# Altium schematic sheet power.SchDoc
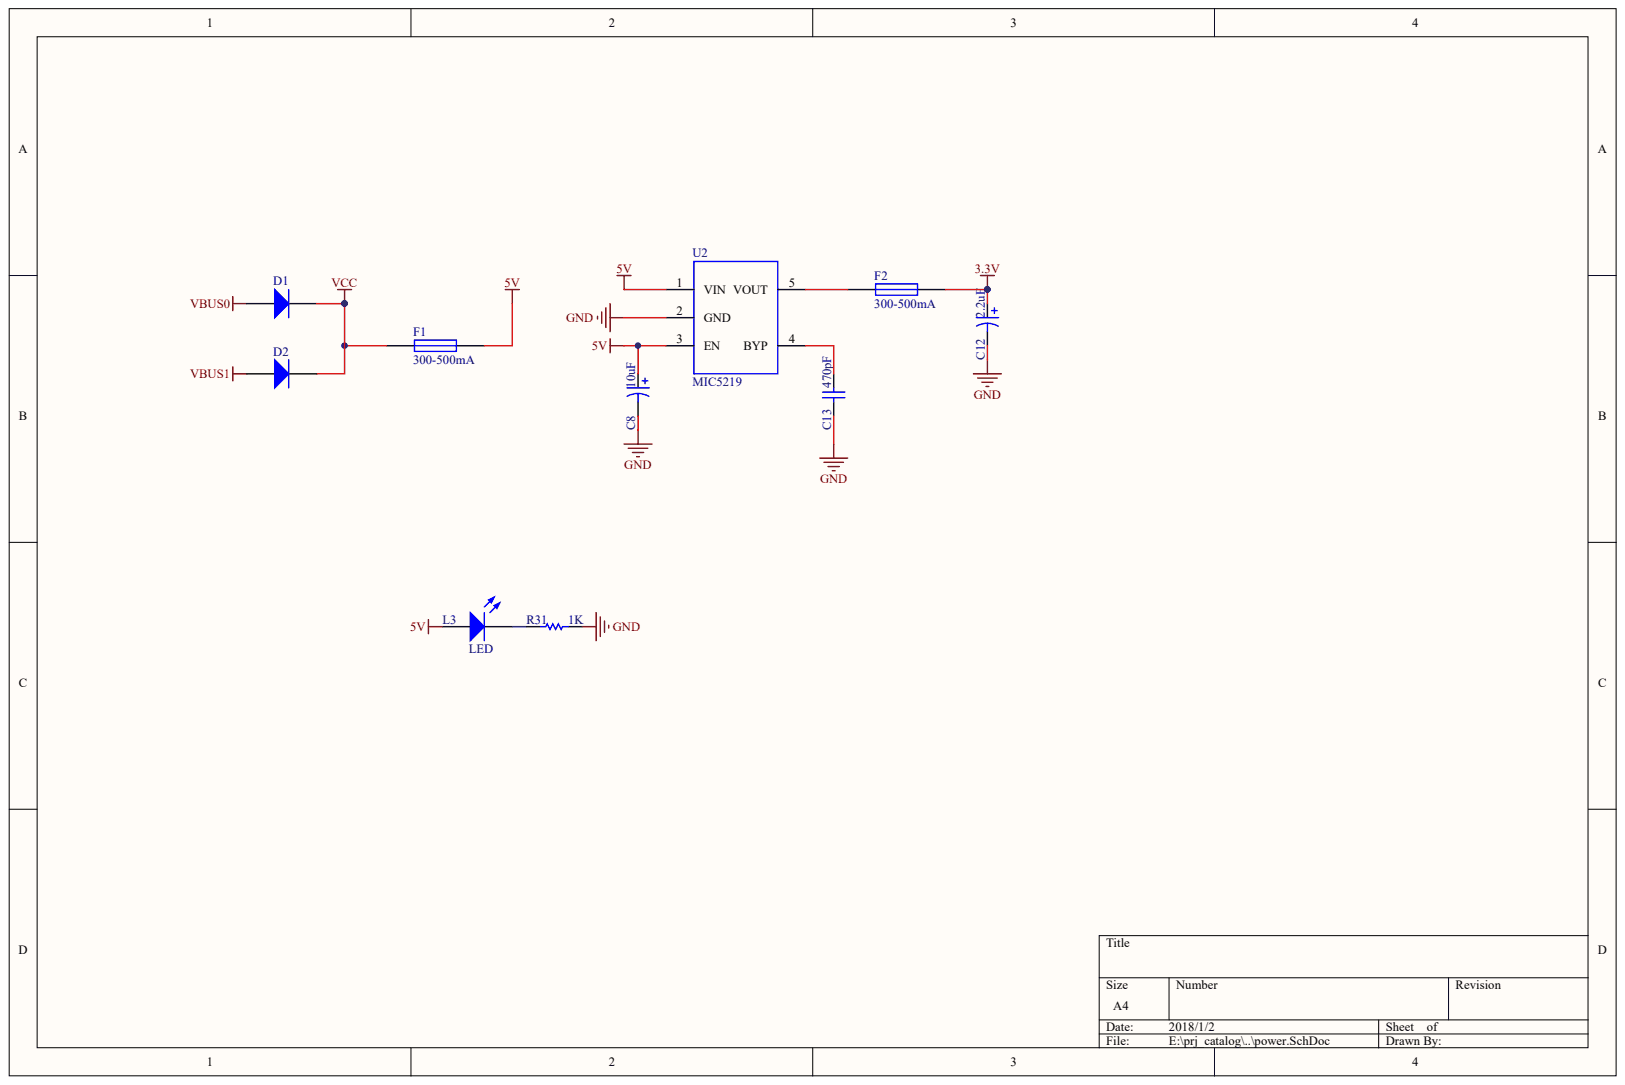
[diagram p0: power.SchDoc]

## Parts
C8  value 10uF  (hidden comment: Cap Pol1)
C12  value 2.2uF  (hidden comment: Cap Pol1)
C13  value 470pF  (hidden comment: Cap)
D1  sk4  (value not drawn)
D2  sk4  (value not drawn)
F1  300-500mA
F2  300-500mA
L3  LED
R31  value 1K  (hidden comment: Res)
U2  MIC5219

## Nets
3.3V: C12.1 F2.2
5V: C8.1 F1.2 L3.1 U2.1(VIN) U2.3(EN)
GND: C8.2 C12.2 C13.1 R31.1 U2.2(GND)
VBUS0: D1.1
VBUS1: D2.1
VCC: D1.2 D2.2 F1.1
NetC13_2: C13.2 U2.4(BYP)
NetF2_1: F2.1 U2.5(VOUT)
NetL3_2: L3.2 R31.2

## Library data of the parts
C8 C12 C13 D1 D2 F1 F2 L3 R31 U2 = (no symbol name)
  C8 C12: Published: 8-Jun-2000; LatestRevisionDate: 17-Jul-2002; LatestRevisionNote: Re-released for DXP Platform.; PackageReference: RB7.6-15; PackageDescription: Polarized Capacitor (Radial); 2 Leads
  C13: Published: 8-Jun-2000; LatestRevisionDate: 17-Jul-2002; LatestRevisionNote: Re-released for DXP Platform.; PackageReference: RAD-0.3
  D1 D2: Published: 8-Jun-2000; LatestRevisionDate: 17-Jul-2002; LatestRevisionNote: Re-released for DXP Platform.; DatasheetVersion: 1998; PackageVersion: Aug-1999; PackageReference: DO-41; PackageDescription: Diode, Axial; Body 5.2 x 2.7 mm (LxDia. max), Lead Dia. 0.86 mm (max); Code_JEDEC: DO-204-AL; ComponentLink1Description: Manufacturer Link; ComponentLink1URL: http://www.fairchildsemi.com/; ComponentLink2Description: Datasheet; ComponentLink2URL: http://www.fairchildsemi.com/ds/1N%2F1N4001.pdf
  F1 F2: Published: 8-Jun-2000; LatestRevisionDate: 17-Jul-2002; LatestRevisionNote: Re-released for DXP Platform.; PackageReference: PIN-W2/E2.8
  R31: Published: 8-Jun-2000; LatestRevisionDate: 17-Jul-2002; LatestRevisionNote: Re-released for DXP Platform.; PackageReference: AXIAL-0.3
  C8 C12 C13 D1 D2 F1 F2 R31: Publisher: Altium Limited
